# Altium schematic sheet Desk.SchDoc(Desk)
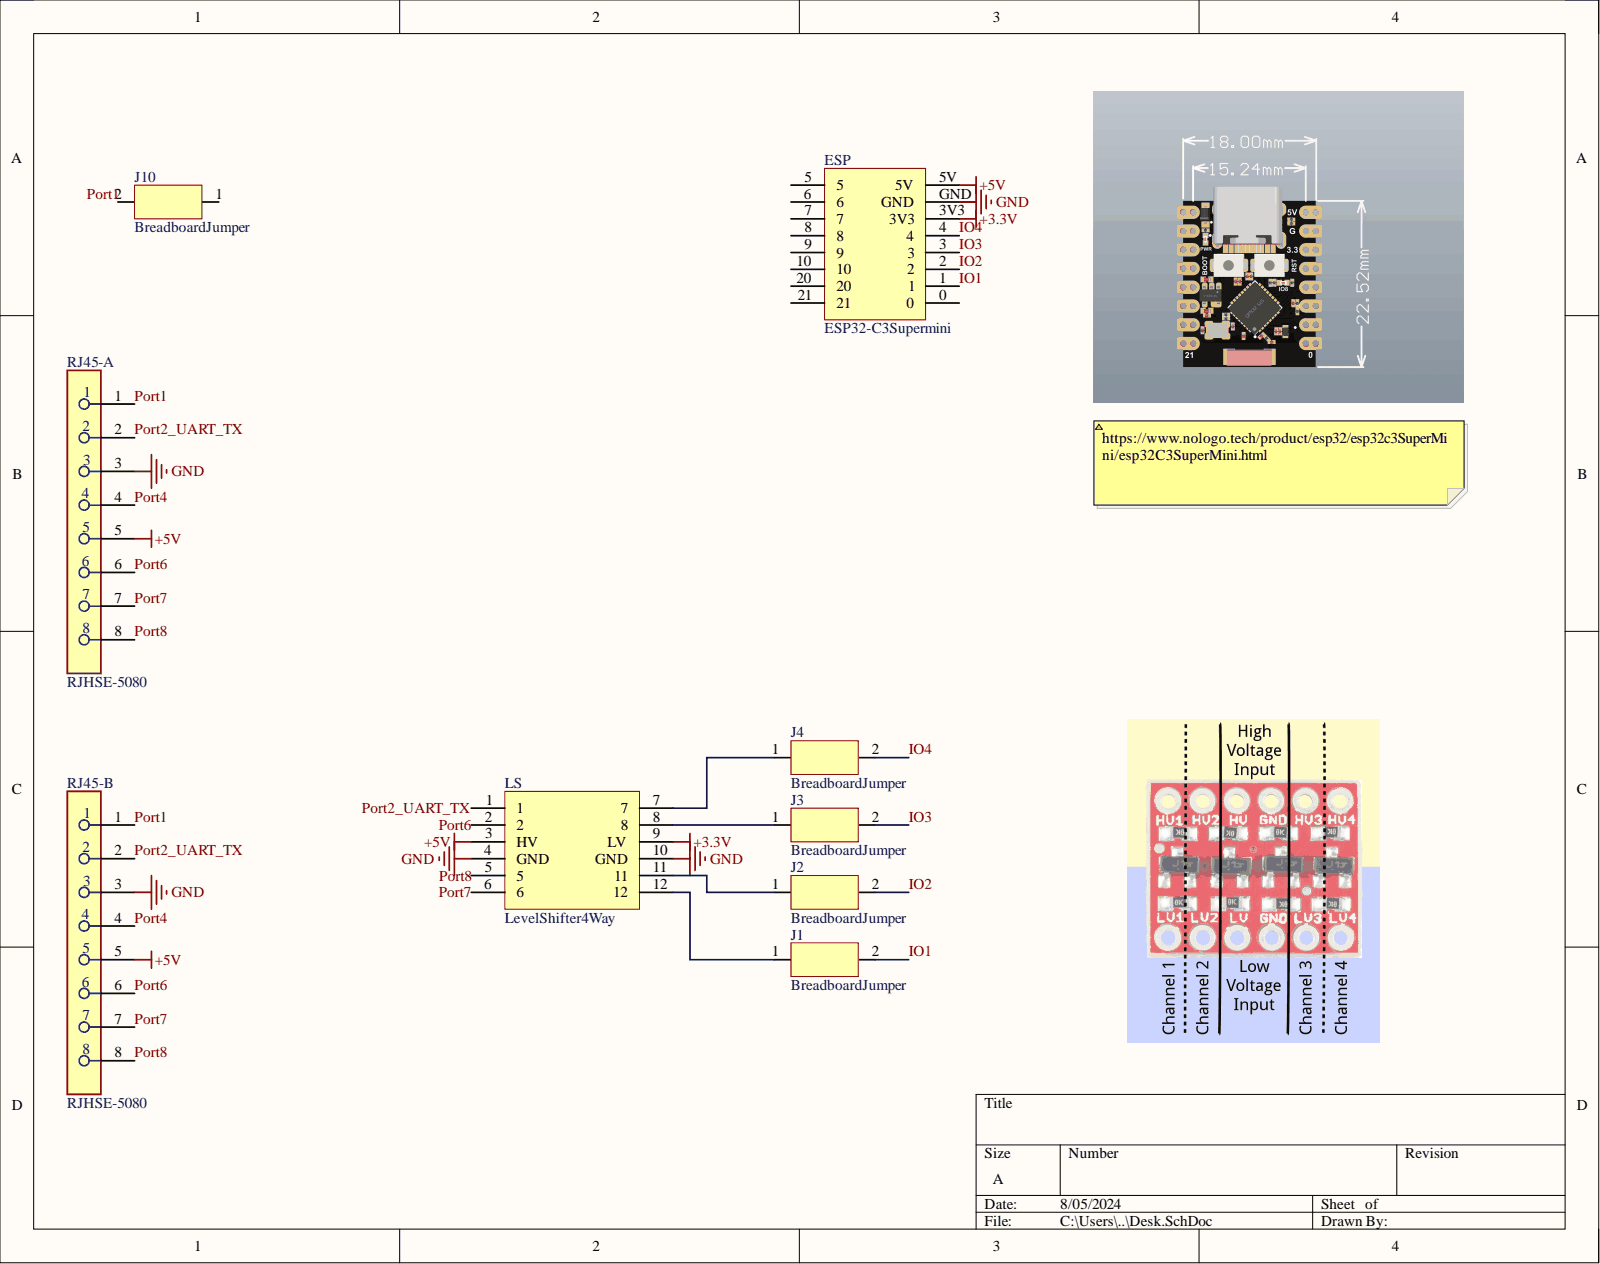
[diagram p0: Desk.SchDoc]

## Parts
ESP  ESP32-C3Supermini  [ESP32-C3 SuperMini]
J1  BreadboardJumper  [BreadboardJumper]
J2  BreadboardJumper  [BreadboardJumper]
J3  BreadboardJumper  [BreadboardJumper]
J4  BreadboardJumper  [BreadboardJumper]
J10  BreadboardJumper  [BreadboardJumper]
LS  LevelShifter4Way  [LevelShifter4Way]
RJ45-A  RJHSE-5080  [FP-RJHSE-5080-MFG]
RJ45-B  RJHSE-5080  [FP-RJHSE-5080-MFG]

## Nets
+3.3V: ESP.3V3 LS.9(LV)
+5V: ESP.5V LS.3(HV) RJ45-A.5 RJ45-B.5
GND: ESP.GND LS.4(GND) LS.10(GND) RJ45-A.3 RJ45-B.3
IO1: ESP.1 J1.2
IO2: ESP.2 J2.2
IO3: ESP.3 J3.2
IO4: ESP.4 J4.2
Port1: J10.2 RJ45-A.1 RJ45-B.1
Port2_UART_TX: LS.1 RJ45-A.2 RJ45-B.2
Port4: RJ45-A.4 RJ45-B.4
Port6: LS.2 RJ45-A.6 RJ45-B.6
Port7: LS.6 RJ45-A.7 RJ45-B.7
Port8: LS.5 RJ45-A.8 RJ45-B.8
NetJ1_1: J1.1 LS.12
NetJ2_1: J2.1 LS.11
NetJ3_1: J3.1 LS.8
NetJ4_1: J4.1 LS.7

Not connected: ESP 0 5 6 7 8 9 10 20 21; J10 1

## Library data of the parts
RJ45-A RJ45-B = CMP-02366-000003-1: CONN MOD JACK 8P8C R/A UNSHLD; Manufacturer: Amphenol; Conn Gender: Female; Conn Orientation: Right Angle; Current Rating: 1.5A; Is Female: yes; Number Of Contacts: 8; Pitch: 1.02mm; Voltage Rating: 125V; Automotive: No; Category: Conn; Component Kind: Standard; ComponentLink2Description: Datasheet; ComponentLink2URL: https://cdn.amphenol-icc.com/media/wysiwyg/files/documentation/datasheet/inputoutput/io-modjack-rjhse.pdf; Contact Material: Gold,Nickel; Device Class L1: Connectors; Device Class L2: Modular / Ethernet Connectors; Device Class L3: unset; Digikey Description: CONN MOD JACK 8P8C R/A UNSHLD; Footprint Url: https://cdn.amphenol-icc.com/media/wysiwyg/files/drawing/rjhse5080.pdf?__cf_chl_jschl_tk__=e349db0bbbb6188a39347cd5e541b92fa02c9719-1587748343-0-AW7tChdeHzRPLX9gSWj7WrKaXQoY9BsmT9Ek0h-T62M9y3Rs9iypFcOtkzb-6sGYQEjFE-jcoNciDETCOZrh1rYyOesac5SvYLoLwHIsHvF4AKBlx-MXmfZUVk9OTTJLqyQq2qMm0PFRLJpNcK0nrKResqOniRSYixG2sPTmOPraH-ULJFBDL602CHqcI9KKBgTq90Jh1OL2Td4moJ99rFsJRJ1Tk_uoxGe8ublcP_ARu-uLpWcQrBcwOcHDTAwL29DAwVaqcH7ta8Ks7WMlHmVl_pILeEDwT0bE9vu5Pa5S3PtNRF1leuFkgi_FMbUxTDulc5xzbXSfng8srUIV1lWXny5nx1fZJEUa_w97yKjffzBMxiWLWVN-V7-uqAaPbyv_m-wRyw5O25OZNyJOeGU; Height: 0.535inch; Lead Free: Yes; Library Name: Altium Content Vault; Manufacturer Part Number: RJHSE-5080; Mouser Description: Modular Connectors / Ethernet Connectors R/A RJ45 NONSHIELDED 8 CONT NO LEDS; Octopart: https://octopart.com/rjhse-5080-amphenol-45060; Package: CONN_MOD_JACK; RoHS: TRUE; Temperature: -55°C to +85°C; Temperature Range High: +85°C; Temperature Range Low: -55°C
ESP = ESP32-C3Supermini: Component Kind: Standard; Library Name: OtherComponents.SchLib
J1-J4 J10 = BreadboardJumper: Component Kind: Net Tie; Library Name: SimpleComponents.SchLib
LS = LevelShifter4Way: Component Kind: Standard; Library Name: OtherComponents.SchLib
